# Altium schematic sheet MEMs and Amplifier.SchDoc
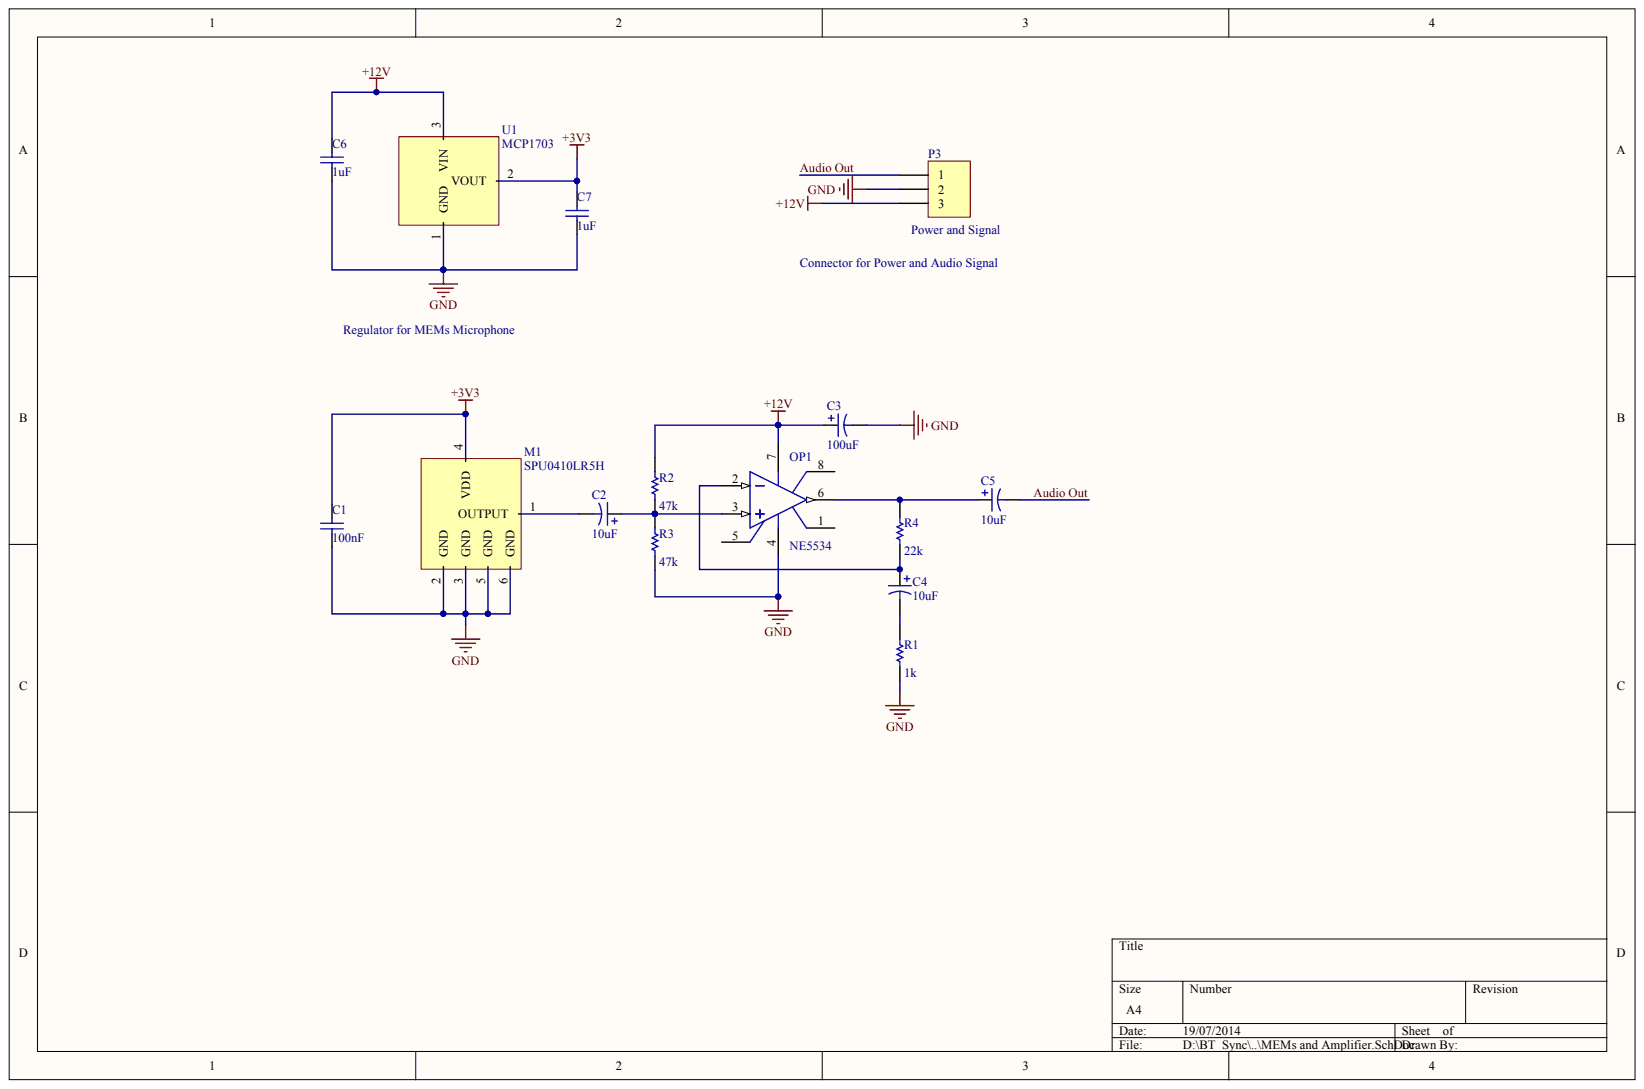
[diagram p0: MEMs and Amplifier.SchDoc]

## Parts
C1  value 100nF  (hidden comment: Cap)
C2  value 10uF  (hidden comment: Cap Pol1)
C3  value 100uF  (hidden comment: Cap Pol1)
C4  value 10uF  (hidden comment: Cap Pol1)
C5  value 10uF  (hidden comment: Cap Pol1)
C6  value 1uF  (hidden comment: Cap)
C7  value 1uF  (hidden comment: Cap)
M1  SPU0410LR5H
OP1  NE5534
P3  Power and Signal
R1  value 1k  (hidden comment: Res1)
R2  value 47k  (hidden comment: Res1)
R3  value 47k  (hidden comment: Res1)
R4  value 22k  (hidden comment: Res1)
U1  MCP1703

## Nets
+3V3: C1.2 C7.2 M1.4(VDD) U1.2
+12V: C3.1 C6.2 OP1.7 P3.3 R2.2 U1.3
GND: C1.1 C3.2 C6.1 C7.1 M1.2 M1.3 M1.5 M1.6 OP1.4 P3.2 R1.1 R3.1 U1.1
AUDIO OUT: C5.2 P3.1
NetC2_1: C2.1 OP1.3 R2.1 R3.2
NetC2_2: C2.2 M1.1(OUTPUT)
NetC4_1: C4.1 OP1.2 R4.1
NetC4_2: C4.2 R1.2
NetC5_1: C5.1 OP1.6 R4.2

Not connected: OP1 1 5 8

## Library data of the parts
C1 = (no symbol name): Type: Ceramic COG; Farnell Code: 8820210
U1 = (no symbol name): Voltage: 3.3V; Farnell Code: 1439518
C2-C7 M1 OP1 P3 R1-R4 = (no symbol name)
  C2-C5: Published: 8-Jun-2000; LatestRevisionDate: 17-Jul-2002; LatestRevisionNote: Re-released for DXP Platform.; PackageReference: RB7.6-15; PackageDescription: Polarized Capacitor (Radial); 2 Leads
  OP1: Published: 8-Jun-2000; LatestRevisionDate: 17-Jul-2002; LatestRevisionNote: Re-released for DXP Platform.; DatasheetVersion: REV. B; PackageVersion: 1990; PackageReference: H-08A; PackageDescription: Can; 8 Leads; Pin Circle Dia. 5.08 mm; Lead Dia. 0.53 mm (max); ComponentLink1Description: Manufacturer Link; ComponentLink1URL: http://www.analog.com/
  P3: LatestRevisionDate: 17-Jul-2002; LatestRevisionNote: Re-released for DXP Platform.
  R1-R4: Published: 8-Jun-2000; LatestRevisionDate: 17-Jul-2002; LatestRevisionNote: Re-released for DXP Platform.; PackageReference: AXIAL-0.3; PackageDescription: Axial Device, Thru-Hole; 2 Leads; 0.3 in Pin Spacing
  C2-C5 OP1 P3 R1-R4: Publisher: Altium Limited
